# Altium schematic sheet beagle_cape_rev3.SchDoc
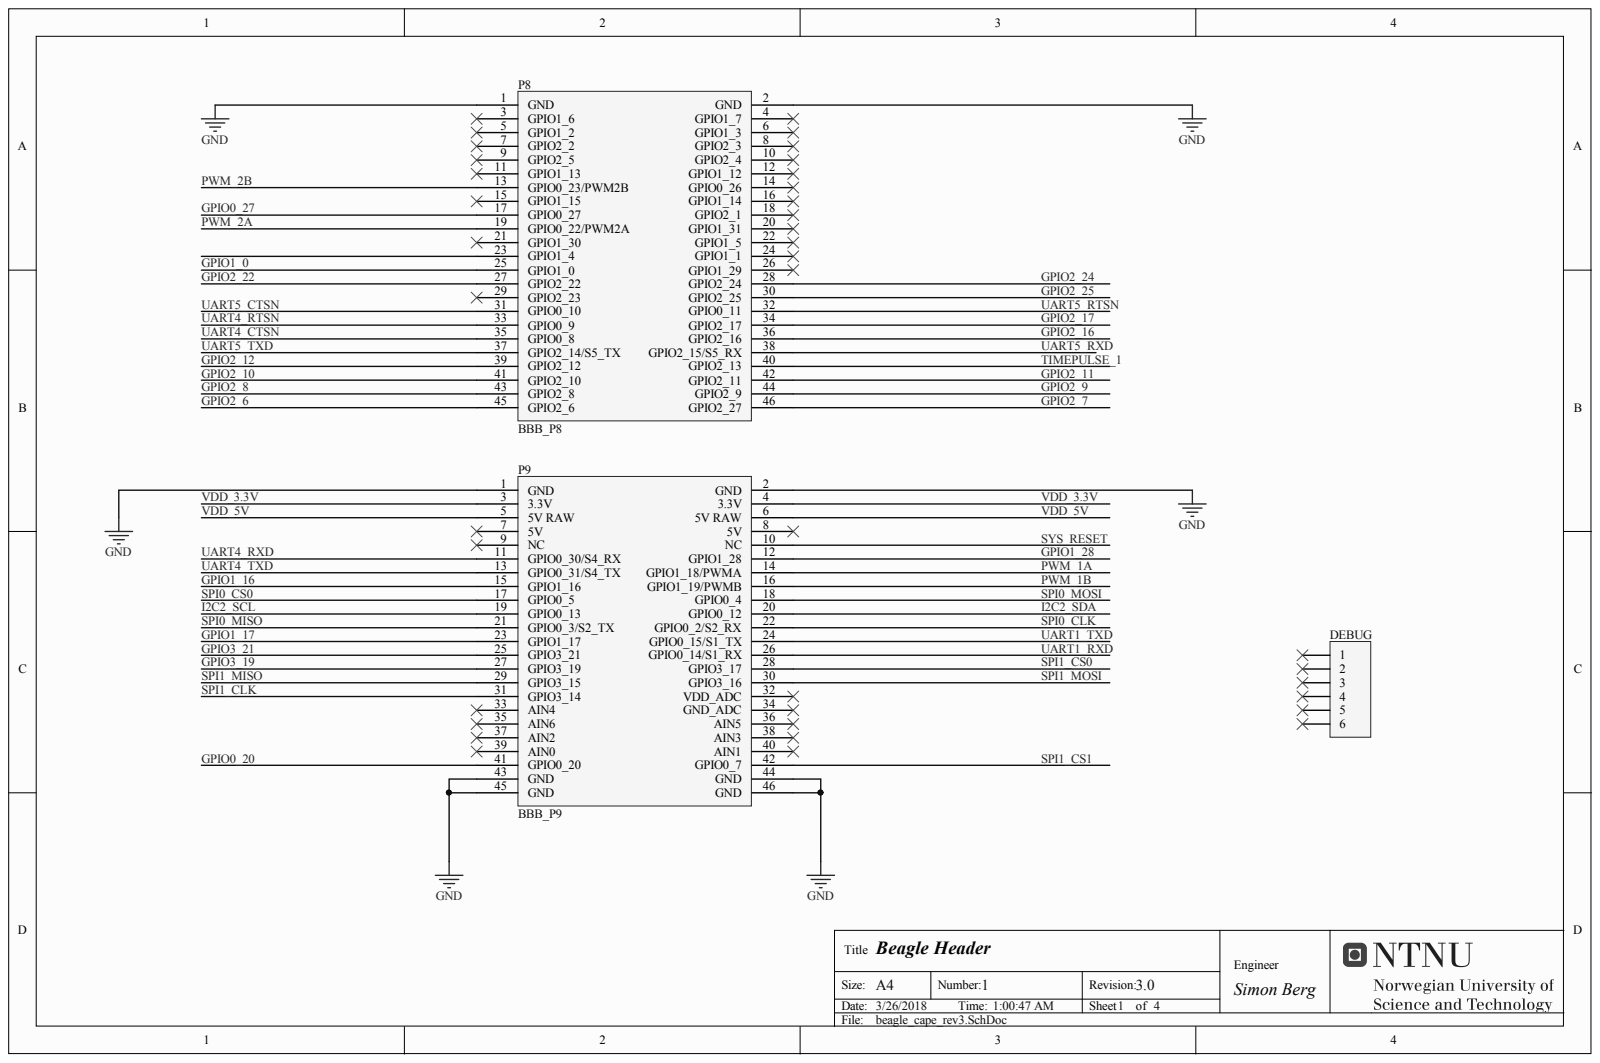
[diagram p0: beagle_cape_rev3.SchDoc]

## Parts
DEBUG  Header 6  [HDR1X6]  (value not drawn)
P8  BBB_P8  [BBB_CAPE_HEADER]
P9  BBB_P9  [BBB_CAPE_HEADER]

## Nets
GND: P8.1(GND) P8.2(GND) P9.1(GND) P9.2(GND) P9.43(GND) P9.44(GND) P9.45(GND) P9.46(GND)
GPIO0_20: P9.41(GPIO0_20)
GPIO0_27: P8.17(GPIO0_27)
GPIO1_0: P8.25(GPIO1_0)
GPIO1_16: P9.15(GPIO1_16)
GPIO1_17: P9.23(GPIO1_17)
GPIO1_28: P9.12(GPIO1_28)
GPIO2_6: P8.45(GPIO2_6)
GPIO2_7: P8.46(GPIO2_27)
GPIO2_8: P8.43(GPIO2_8)
GPIO2_9: P8.44(GPIO2_9)
GPIO2_10: P8.41(GPIO2_10)
GPIO2_11: P8.42(GPIO2_11)
GPIO2_12: P8.39(GPIO2_12)
GPIO2_16: P8.36(GPIO2_16)
GPIO2_17: P8.34(GPIO2_17)
GPIO2_22: P8.27(GPIO2_22)
GPIO2_24: P8.28(GPIO2_24)
GPIO2_25: P8.30(GPIO2_25)
GPIO3_19: P9.27(GPIO3_19)
GPIO3_21: P9.25(GPIO3_21)
I2C2_SCL: P9.19(GPIO0_13)
I2C2_SDA: P9.20(GPIO0_12)
PWM_1A: P9.14(GPIO1_18/PWMA)
PWM_1B: P9.16(GPIO1_19/PWMB)
PWM_2A: P8.19(GPIO0_22/PWM2A)
PWM_2B: P8.13(GPIO0_23/PWM2B)
SPI0_CLK: P9.22(GPIO0_2/S2_RX)
SPI0_CS0: P9.17(GPIO0_5)
SPI0_MISO: P9.21(GPIO0_3/S2_TX)
SPI0_MOSI: P9.18(GPIO0_4)
SPI1_CLK: P9.31(GPIO3_14)
SPI1_CS0: P9.28(GPIO3_17)
SPI1_CS1: P9.42(GPIO0_7)
SPI1_MISO: P9.29(GPIO3_15)
SPI1_MOSI: P9.30(GPIO3_16)
SYS_RESET: P9.10(NC)
TIMEPULSE_1: P8.40(GPIO2_13)
UART1_RXD: P9.26(GPIO0_14/S1_RX)
UART1_TXD: P9.24(GPIO0_15/S1_TX)
UART4_CTSN: P8.35(GPIO0_8)
UART4_RTSN: P8.33(GPIO0_9)
UART4_RXD: P9.11(GPIO0_30/S4_RX)
UART4_TXD: P9.13(GPIO0_31/S4_TX)
UART5_CTSN: P8.31(GPIO0_10)
UART5_RTSN: P8.32(GPIO0_11)
UART5_RXD: P8.38(GPIO2_15/S5_RX)
UART5_TXD: P8.37(GPIO2_14/S5_TX)
VDD_3.3V: P9.3(3.3V) P9.4(3.3V)
VDD_5V: P9.5(5V_RAW) P9.6(5V_RAW)

Not connected: DEBUG 1 2 3 4 5 6; P8 3(GPIO1_6) 4(GPIO1_7) 5(GPIO1_2) 6(GPIO1_3) 7(GPIO2_2) 8(GPIO2_3) 9(GPIO2_5) 10(GPIO2_4) 11(GPIO1_13) 12(GPIO1_12) 14(GPIO0_26) 15(GPIO1_15) 16(GPIO1_14) 18(GPIO2_1) 20(GPIO1_31) 21(GPIO1_30) 22(GPIO1_5) 23(GPIO1_4) 24(GPIO1_1) 26(GPIO1_29) 29(GPIO2_23); P9 7(5V) 8(5V) 9(NC) 32(VDD_ADC) 33(AIN4) 34(GND_ADC) 35(AIN6) 36(AIN5) 37(AIN2) 38(AIN3) 39(AIN0) 40(AIN1)

## Library data of the parts
DEBUG = Header 6: Header, 6-Pin; Component Kind: Standard; LatestRevisionDate: 17-Jul-2002; LatestRevisionNote: Re-released for DXP Platform.; Library Name: Miscellaneous Connectors.IntLib; Publisher: Altium Limited
P8 = BBB_P8: Component Kind: Standard; Library Name: BeagleBoneCape.SchLib
P9 = BBB_P9: Component Kind: Standard; Library Name: BeagleBoneCape.SchLib
